# Altium schematic sheet Controller Board - Microcontroller.SchDoc
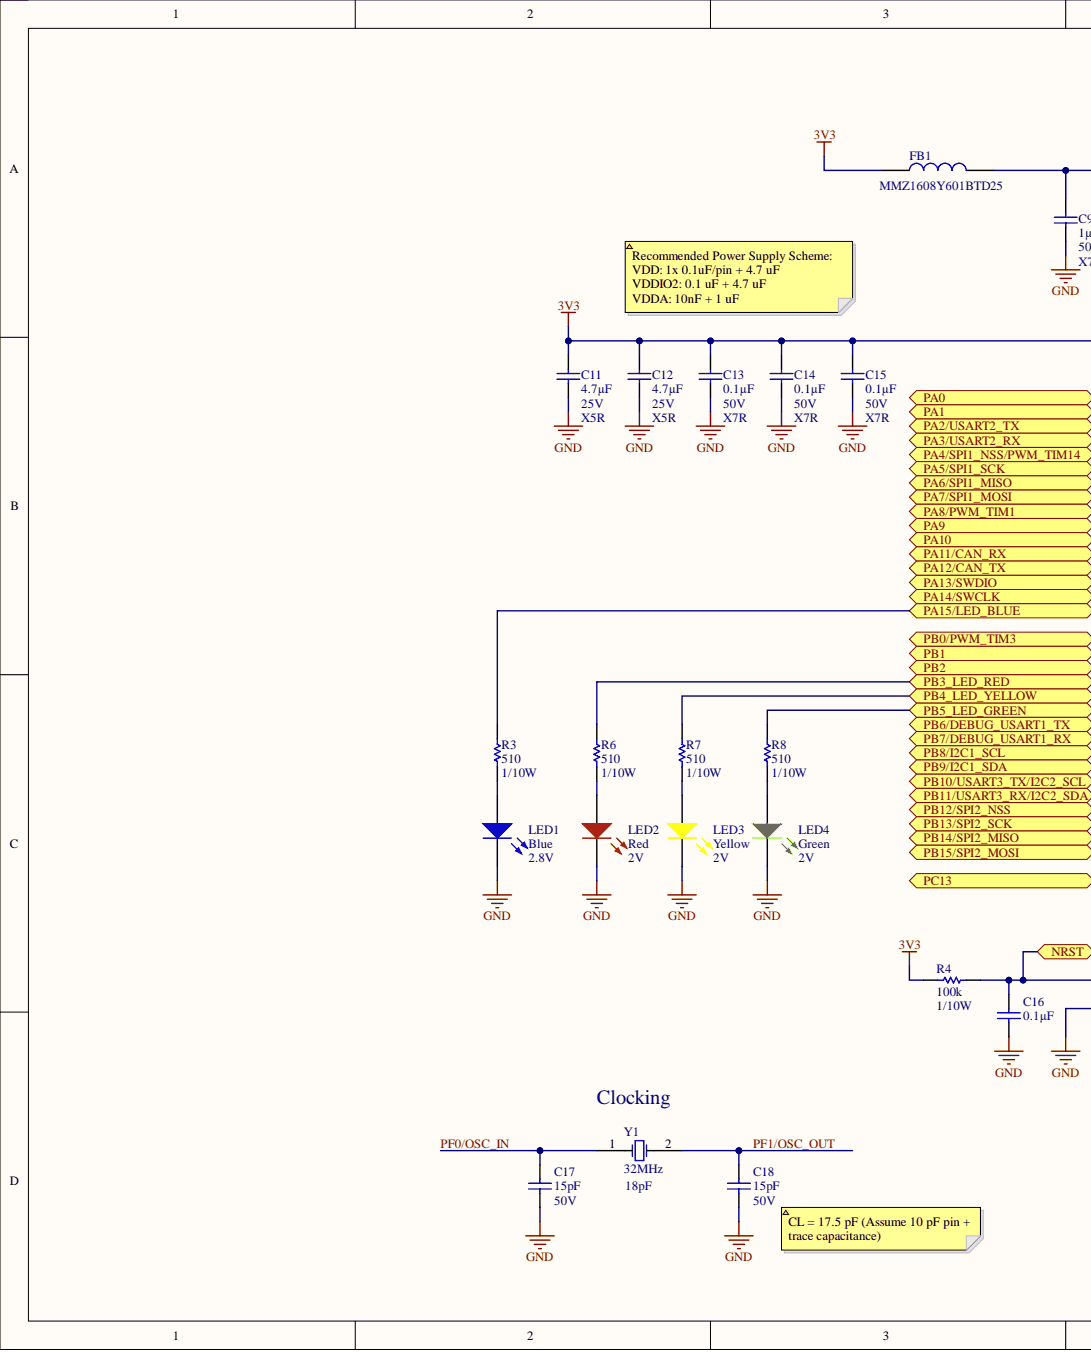
[diagram p0: Controller Board - Microcontroller.SchDoc, left]
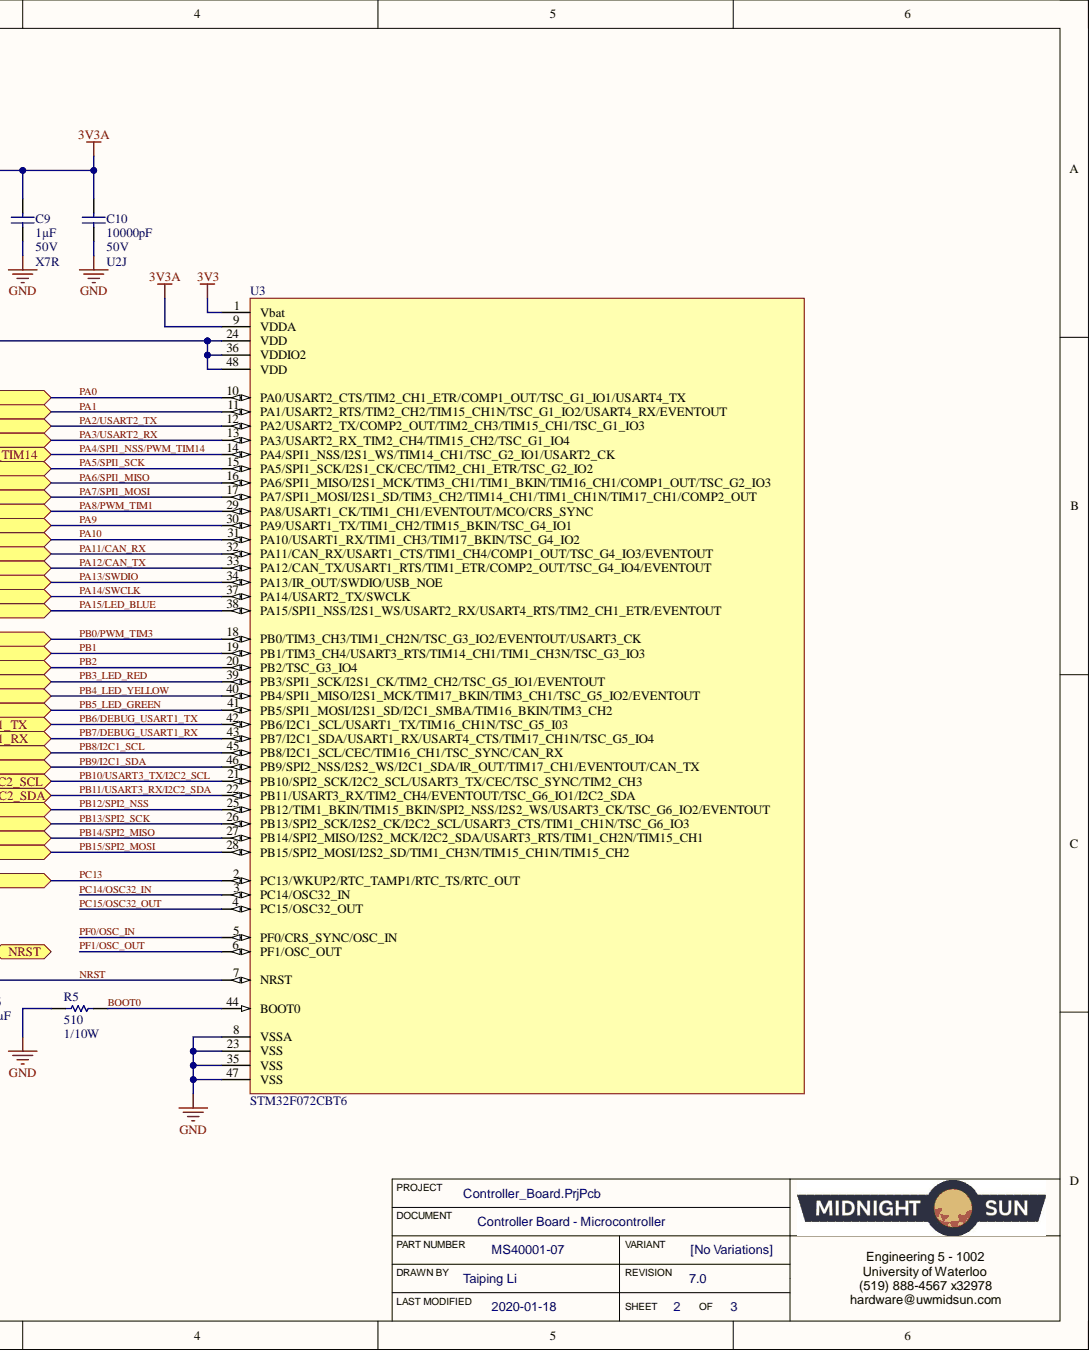
[diagram p1: Controller Board - Microcontroller.SchDoc, right]

## Parts
C9  Capacitance: 1µF  Temperature Coefficient: X7R  Voltage: 50V  [CAP, 0603]  (hidden comment: CAP CER 1UF 50V 10% X7R 0603)
C10  Capacitance: 10000pF  Temperature Coefficient: U2J  Voltage: 50V  [CAP, 0603]  (hidden comment: CAP CER 10nF 50V 5% X7R 0603)
C11  Capacitance: 4.7µF  Temperature Coefficient: X5R  Voltage: 25V  [CAP, 0603]  (hidden comment: CAP CER 4.7UF 25V 10% X5R 0603)
C12  Capacitance: 4.7µF  Temperature Coefficient: X5R  Voltage: 25V  [CAP, 0603]  (hidden comment: CAP CER 4.7UF 25V 10% X5R 0603)
C13  Capacitance: 0.1µF  Temperature Coefficient: X7R  Voltage: 50V  [CAP, 0603]  (hidden comment: CAP CER 0.1UF 50V 10% X7R 0603)
C14  Capacitance: 0.1µF  Temperature Coefficient: X7R  Voltage: 50V  [CAP, 0603]  (hidden comment: CAP CER 0.1UF 50V 10% X7R 0603)
C15  Capacitance: 0.1µF  Temperature Coefficient: X7R  Voltage: 50V  [CAP, 0603]  (hidden comment: CAP CER 0.1UF 50V 10% X7R 0603)
C16  Capacitance: 0.1µF  [CAP, 0603]  (hidden comment: CAP CER 0.1UF 50V 10% X7R 0603)
C17  Capacitance: 15pF  Voltage: 50V  [CAP, 0603]  (hidden comment: CAP CER 15PF 50V ±5% NPO 0603)
C18  Capacitance: 15pF  Voltage: 50V  [CAP, 0603]  (hidden comment: CAP CER 15PF 50V ±5% NPO 0603)
FB1  Manufacturer Part Number: MMZ1608Y601BTD25  [FB 0603]  (hidden comment: FB 600 OHM 1LN 0603)
LED1  Color: Blue  Voltage - Forward (Vf) (Typ): 2.8V  [LED_0603]  (hidden comment: LED BLUE CLEAR 2.8V 0603)
LED2  Color: Red  Voltage - Forward (Vf) (Typ): 2V  [LED_0603]  (hidden comment: LED RED CLEAR 2V 0603)
LED3  Color: Yellow  Voltage - Forward (Vf) (Typ): 2V  [LED_0603]  (hidden comment: LED YELLOW CLEAR 2.1V 0603)
LED4  Color: Green  Voltage - Forward (Vf) (Typ): 2V  [LED_0603]  (hidden comment: LED GREEN CLEAR 2V 0603)
R3  Power: 1/10W  [RES, 0603]  (hidden comment: RES 510 OHM 5% 1/10W 0603)
R4  Power: 1/10W  Resistance: 100k  [RES, 0603]  (hidden comment: RES 100K OHM 5% 1/8W 0603)
R5  Power: 1/10W  [RES, 0603]  (hidden comment: RES 510 OHM 5% 1/10W 0603)
R6  Power: 1/10W  [RES, 0603]  (hidden comment: RES 510 OHM 5% 1/10W 0603)
R7  Power: 1/10W  [RES, 0603]  (hidden comment: RES 510 OHM 5% 1/10W 0603)
R8  Power: 1/10W  [RES, 0603]  (hidden comment: RES 510 OHM 5% 1/10W 0603)
U3  Manufacturer Part Number 1: STM32F072CBT6  [TSQFP50P890X890X160-48M]  (hidden comment: IC MCU 32BIT 128KB FLASH 48LQFP)
Y1  Frequency: 32MHz  Load Capacitance: 18pF  [CRYSTAL ABM7]  (hidden comment: CRYSTAL 32 MHz 18PF 2-SMD)

## Ports
NRST, PA0, PA1, PA2/USART2_TX, PA3/USART2_RX, PA4/SPI1_NSS/PWM_TIM14, PA5/SPI1_SCK, PA6/SPI1_MISO, PA7/SPI1_MOSI, PA8/PWM_TIM1, PA9, PA10, PA11/CAN_RX, PA12/CAN_TX, PA13/SWDIO, PA14/SWCLK, PA15/LED_BLUE, PB0/PWM_TIM3, PB1, PB2, PB3_LED_RED, PB4_LED_YELLOW, PB5_LED_GREEN, PB6/DEBUG_USART1_TX, PB7/DEBUG_USART1_RX, PB8/I2C1_SCL, PB9/I2C1_SDA, PB10/USART3_TX/I2C2_SCL, PB11/USART3_RX/I2C2_SDA, PB12/SPI2_NSS, PB13/SPI2_SCK, PB14/SPI2_MISO, PB15/SPI2_MOSI, PC13

## Nets
3V3: C11.1 C12.1 C13.1 C14.1 C15.1 FB1.1 R4.2 U3.1(Vbat) U3.24(VDD) U3.36(VDDIO2) U3.48(VDD)
3V3A: C9.1 C10.1 FB1.2 U3.9(VDDA)
GND: C9.2 C10.2 C11.2 C12.2 C13.2 C14.2 C15.2 C16.1 C17.1 C18.1 LED1.2 LED2.2 LED3.2 LED4.2 R5.1 U3.8(VSSA) U3.23(VSS) U3.35(VSS) U3.47(VSS)
BOOT0: R5.2 U3.44(BOOT0)
NRST: C16.2 R4.1 U3.7(NRST) ; port NRST
PA0: U3.10(PA0/USART2_CTS/TIM2_CH1_ETR/COMP1_OUT/TSC_G1_IO1/USART4_TX) ; port PA0
PA1: U3.11(PA1/USART2_RTS/TIM2_CH2/TIM15_CH1N/TSC_G1_IO2/USART4_RX/EVENTOUT) ; port PA1
PA2/USART2_TX: U3.12(PA2/USART2_TX/COMP2_OUT/TIM2_CH3/TIM15_CH1/TSC_G1_IO3) ; port PA2/USART2_TX
PA3/USART2_RX: U3.13(PA3/USART2_RX_TIM2_CH4/TIM15_CH2/TSC_G1_IO4) ; port PA3/USART2_RX
PA4/SPI1_NSS/PWM_TIM14: U3.14(PA4/SPI1_NSS/I2S1_WS/TIM14_CH1/TSC_G2_IO1/USART2_CK) ; port PA4/SPI1_NSS/PWM_TIM14
PA5/SPI1_SCK: U3.15(PA5/SPI1_SCK/I2S1_CK/CEC/TIM2_CH1_ETR/TSC_G2_IO2) ; port PA5/SPI1_SCK
PA6/SPI1_MISO: U3.16(PA6/SPI1_MISO/I2S1_MCK/TIM3_CH1/TIM1_BKIN/TIM16_CH1/COMP1_OUT/TSC_G2_IO3) ; port PA6/SPI1_MISO
PA7/SPI1_MOSI: U3.17(PA7/SPI1_MOSI/I2S1_SD/TIM3_CH2/TIM14_CH1/TIM1_CH1N/TIM17_CH1/COMP2_OUT) ; port PA7/SPI1_MOSI
PA8/PWM_TIM1: U3.29(PA8/USART1_CK/TIM1_CH1/EVENTOUT/MCO/CRS_SYNC) ; port PA8/PWM_TIM1
PA9: U3.30(PA9/USART1_TX/TIM1_CH2/TIM15_BKIN/TSC_G4_IO1) ; port PA9
PA10: U3.31(PA10/USART1_RX/TIM1_CH3/TIM17_BKIN/TSC_G4_IO2) ; port PA10
PA11/CAN_RX: U3.32(PA11/CAN_RX/USART1_CTS/TIM1_CH4/COMP1_OUT/TSC_G4_IO3/EVENTOUT) ; port PA11/CAN_RX
PA12/CAN_TX: U3.33(PA12/CAN_TX/USART1_RTS/TIM1_ETR/COMP2_OUT/TSC_G4_IO4/EVENTOUT) ; port PA12/CAN_TX
PA13/SWDIO: U3.34(PA13/IR_OUT/SWDIO/USB_NOE) ; port PA13/SWDIO
PA14/SWCLK: U3.37(PA14/USART2_TX/SWCLK) ; port PA14/SWCLK
PA15/LED_BLUE: R3.1 U3.38(PA15/SPI1_NSS/I2S1_WS/USART2_RX/USART4_RTS/TIM2_CH1_ETR/EVENTOUT) ; port PA15/LED_BLUE
PB0/PWM_TIM3: U3.18(PB0/TIM3_CH3/TIM1_CH2N/TSC_G3_IO2/EVENTOUT/USART3_CK) ; port PB0/PWM_TIM3
PB1: U3.19(PB1/TIM3_CH4/USART3_RTS/TIM14_CH1/TIM1_CH3N/TSC_G3_IO3) ; port PB1
PB2: U3.20(PB2/TSC_G3_IO4) ; port PB2
PB3_LED_RED: R6.1 U3.39(PB3/SPI1_SCK/I2S1_CK/TIM2_CH2/TSC_G5_IO1/EVENTOUT) ; port PB3_LED_RED
PB4_LED_YELLOW: R7.1 U3.40(PB4/SPI1_MISO/I2S1_MCK/TIM17_BKIN/TIM3_CH1/TSC_G5_IO2/EVENTOUT) ; port PB4_LED_YELLOW
PB5_LED_GREEN: R8.1 U3.41(PB5/SPI1_MOSI/I2S1_SD/I2C1_SMBA/TIM16_BKIN/TIM3_CH2) ; port PB5_LED_GREEN
PB6/DEBUG_USART1_TX: U3.42(PB6/I2C1_SCL/USART1_TX/TIM16_CH1N/TSC_G5_I03) ; port PB6/DEBUG_USART1_TX
PB7/DEBUG_USART1_RX: U3.43(PB7/I2C1_SDA/USART1_RX/USART4_CTS/TIM17_CH1N/TSC_G5_IO4) ; port PB7/DEBUG_USART1_RX
PB8/I2C1_SCL: U3.45(PB8/I2C1_SCL/CEC/TIM16_CH1/TSC_SYNC/CAN_RX) ; port PB8/I2C1_SCL
PB9/I2C1_SDA: U3.46(PB9/SPI2_NSS/I2S2_WS/I2C1_SDA/IR_OUT/TIM17_CH1/EVENTOUT/CAN_TX) ; port PB9/I2C1_SDA
PB10/USART3_TX/I2C2_SCL: U3.21(PB10/SPI2_SCK/I2C2_SCL/USART3_TX/CEC/TSC_SYNC/TIM2_CH3) ; port PB10/USART3_TX/I2C2_SCL
PB11/USART3_RX/I2C2_SDA: U3.22(PB11/USART3_RX/TIM2_CH4/EVENTOUT/TSC_G6_IO1/I2C2_SDA) ; port PB11/USART3_RX/I2C2_SDA
PB12/SPI2_NSS: U3.25(PB12/TIM1_BKIN/TIM15_BKIN/SPI2_NSS/I2S2_WS/USART3_CK/TSC_G6_IO2/EVENTOUT) ; port PB12/SPI2_NSS
PB13/SPI2_SCK: U3.26(PB13/SPI2_SCK/I2S2_CK/I2C2_SCL/USART3_CTS/TIM1_CH1N/TSC_G6_IO3) ; port PB13/SPI2_SCK
PB14/SPI2_MISO: U3.27(PB14/SPI2_MISO/I2S2_MCK/I2C2_SDA/USART3_RTS/TIM1_CH2N/TIM15_CH1) ; port PB14/SPI2_MISO
PB15/SPI2_MOSI: U3.28(PB15/SPI2_MOSI/I2S2_SD/TIM1_CH3N/TIM15_CH1N/TIM15_CH2) ; port PB15/SPI2_MOSI
PC13: U3.2(PC13/WKUP2/RTC_TAMP1/RTC_TS/RTC_OUT) ; port PC13
PC14/OSC32_IN: U3.3(PC14/OSC32_IN)
PC15/OSC32_OUT: U3.4(PC15/OSC32_OUT)
PF0/OSC_IN: C17.2 U3.5(PF0/CRS_SYNC/OSC_IN) Y1.1
PF1/OSC_OUT: C18.2 U3.6(PF1/OSC_OUT) Y1.2
NetLED1_1: LED1.1 R3.2
NetLED2_1: LED2.1 R6.2
NetLED3_1: LED3.1 R7.2
NetLED4_1: LED4.1 R8.2

## Library data of the parts
C9 = CAP CER 1UF 50V 10% X7R 0603: 1µF ±10% 50V Ceramic Capacitor X7R 0603 (1608 Metric); Manufacturer 1: Taiyo Yuden; Manufacturer Part Number 1: UMK107AB7105KA-T; Component Kind: Standard; ComponentLink1Description: http://www.yuden.co.jp/productdata/catalog/en/mlcc_all_e.pdf; ComponentLink1URL: http://www.yuden.co.jp/productdata/catalog/en/mlcc_all_e.pdf; Height - Seated (Max): -; Lead Spacing: -; Lead Style: -; Mounting Type: Surface Mount, MLCC; Operating Temperature: -55°C ~ 125°C; Package / Case: 0603 (1608 Metric); Packaging: Cut Tape (CT); Part Status: Active; Ratings: -; Size / Dimension: 0.063" L x 0.031" W (1.60mm x 0.80mm); Supplier 1: Digi-Key; Supplier Part Number 1: 587-3247-1-ND; Thickness (Max): 0.037" (0.95mm); Tolerance: ±10%; Library Name: Schematic Diagrams.SchLib
C10 = CAP CER 10nF 50V 5% X7R 0603: 10000pF ±5% 50V Ceramic Capacitor U2J 0603 (1608 Metric); Manufacturer 1: KEMET; Manufacturer Part Number 1: C0603C103J5JAC7867; Component Kind: Standard; ComponentLink1Description: http://www.kemet.com/docfinder?Partnumber=C0603C103J5JAC7867; ComponentLink1URL: http://www.kemet.com/docfinder?Partnumber=C0603C103J5JAC7867; Failure Rate: -; Height - Seated (Max): -; Lead Spacing: -; Lead Style: -; Mounting Type: Surface Mount, MLCC; Operating Temperature: -55°C ~ 125°C; Package / Case: 0603 (1608 Metric); Packaging: Cut Tape (CT); Part Status: Active; Ratings: -; Size / Dimension: 0.063" L x 0.032" W (1.60mm x 0.80mm); Supplier 1: Digi-Key; Supplier Part Number 1: 399-13384-1-ND; Thickness (Max): 0.034" (0.87mm); Tolerance: ±5%; Library Name: Schematic Diagrams.SchLib
C11 C12 = CAP CER 4.7UF 25V 10% X5R 0603: 4.7µF ±10% 25V Ceramic Capacitor X5R 0603 (1608 Metric); Manufacturer 1: Murata Electronics North America; Manufacturer Part Number 1: GRM188R61E475KE11D; Component Kind: Standard; ComponentLink1Description: http://www.murata.com/~/media/webrenewal/support/library/catalog/products/capacitor/mlcc/c02e.ashx; ComponentLink1URL: http://www.murata.com/~/media/webrenewal/support/library/catalog/products/capacitor/mlcc/c02e.ashx; Height - Seated (Max): -; Lead Spacing: -; Lead Style: -; Mounting Type: Surface Mount, MLCC; Operating Temperature: -55°C ~ 85°C; Package / Case: 0603 (1608 Metric); Packaging: Cut Tape (CT); Part Status: Active; Ratings: -; Size / Dimension: 0.063" L x 0.031" W (1.60mm x 0.80mm); Supplier 1: Digi-Key; Supplier Part Number 1: 490-7203-1-ND; Thickness (Max): 0.031" (0.80mm); Tolerance: ±10%; Library Name: Schematic Diagrams.SchLib
C13-C16 = CAP CER 0.1UF 50V 10% X7R 0603: 0.10µF ±10% 50V Ceramic Capacitor X7R 0603 (1608 Metric); Manufacturer 1: AVX Corporation; Manufacturer Part Number 1: 06035C104KAT2A; Component Kind: Standard; ComponentLink1Description: http://datasheets.avx.com/X7RDielectric.pdf; ComponentLink1URL: http://datasheets.avx.com/X7RDielectric.pdf; Height - Seated (Max): -; Lead Spacing: -; Lead Style: -; Mounting Type: Surface Mount, MLCC; Operating Temperature: -55°C ~ 125°C; Package / Case: 0603 (1608 Metric); Packaging: Cut Tape (CT); Part Status: Active; Ratings: -; Size / Dimension: 0.063" L x 0.032" W (1.60mm x 0.81mm); Supplier 1: Digi-Key; Supplier Part Number 1: 478-5052-1-ND; Thickness (Max): 0.035" (0.90mm); Tolerance: ±10%; Library Name: Schematic Diagrams.SchLib
  C16: Temperature Coefficient: X7R; Voltage: 50V
C17 C18 = CAP CER 15PF 50V ±5% NPO 0603: 15pF ±5% 50V Ceramic Capacitor C0G, NP0 0603 (1608 Metric); Manufacturer 1: Yageo; Manufacturer Part Number 1: CC0603JRNPO9BN150; Component Kind: Standard; ComponentLink1Description: http://www.yageo.com/documents/recent/UPY-GP_NP0_16V-to-50V_16.pdf; ComponentLink1URL: http://www.yageo.com/documents/recent/UPY-GP_NP0_16V-to-50V_16.pdf; Failure Rate: -; Height - Seated (Max): -; Lead Spacing: -; Lead Style: -; Mounting Type: Surface Mount, MLCC; Operating Temperature: -55°C ~ 125°C; Package / Case: 0603 (1608 Metric); Packaging: Cut Tape (CT); Part Status: Active; Ratings: -; Size / Dimension: 0.063" L x 0.031" W (1.60mm x 0.80mm); Supplier 1: Digi-Key; Supplier Part Number 1: 311-1060-1-ND; Temperature Coefficient: C0G, NP0; Thickness (Max): 0.035" (0.90mm); Tolerance: ±5%; Library Name: Schematic Diagrams.SchLib
FB1 = FB 600 OHM 1LN 0603: FERRITE BEAD 600 OHM 0603 1LN; Manufacturer: TDK; DC Resistance (DCR): 400mR; Impedance: 600R; Max Current Rating: 500mA; Number of Lines: 1; Test Frequency: 100MHz; Tolerance: 25%; Case Code (Imperial): 0603; Case Code (Metric): 1608; Case/Package: 0603; Component Kind: Standard; Height: 0.037inch; Length: 0.063inch; Max DC Current: 500mA; Max Operating Temperature: 125°C; Min Operating Temperature: -55°C; Mount: Surface Mount; Package Quantity: 4000; Packaging: Tape and Reel; Pricing 1: 1 = 0.10000, 10 = 0.07600, 25 = 0.06840, 50 = 0.05000, 100 = 0.04330, 250 = 0.03416, 500 = 0.02884, 1000 = 0.02429 (USD); Ratings: AEC-Q200; RoHS: Yes; Stock 1: 19540; Supplier 1: Digi-Key; Supplier Part Number 1: 445-172850-1-ND; Termination: SMD/SMT; Weight: 7.1E-05oz; Width: 0.031inch; Library Name: Schematic Diagrams.SchLib
LED1 = LED BLUE CLEAR 2.8V 0603: Blue 470nm LED Indication - Discrete 2.8V 0603 (1608 Metric); Manufacturer 1: Lite-On Inc.; Manufacturer Part Number 1: LTST-C193TBKT-5A; Current - Test: 5mA; Lens Color: Colorless; Lens Style/Size: Rectangle with Flat Top, 1.15mm x 0.80mm; Millicandela Rating: 15mcd; Viewing Angle: 130°; Wavelength - Peak: 468nm; Component Kind: Standard; ComponentLink1Description: http://optoelectronics.liteon.com/upload/download/DS22-2004-060/P_100_LTST-C193TBKT-5A.pdf; ComponentLink1URL: http://optoelectronics.liteon.com/upload/download/DS22-2004-060/P_100_LTST-C193TBKT-5A.pdf; Height (Max): 0.45mm; Lens Transparency: Clear; Mounting Type: Surface Mount; Package / Case: 0603 (1608 Metric); Packaging: Cut Tape (CT); Part Status: Active; Size / Dimension: 1.60mm L x 0.80mm W; Supplier 1: Digi-Key; Supplier Device Package: Chip LED; Supplier Part Number 1: 160-1827-1-ND; Wavelength - Dominant: 470nm; Library Name: Schematic Diagrams.SchLib
LED2 = LED RED CLEAR 2V 0603: Red 631nm LED Indication - Discrete 2V 0603 (1608 Metric); Manufacturer: Wurth Electronics Inc.; Manufacturer Part Number: 150060RS75000; Current - Test: 20mA; Lens Color: Colorless; Lens Style/Size: Rectangle with Flat Top, 1.10mm x 0.70mm; Millicandela Rating: 250mcd; Viewing Angle: 140°; Wavelength - Dominant: 625nm; Wavelength - Peak: 630nm; Category: Optoelectronics; Component Kind: Standard; ComponentLink1Description: http://katalog.we-online.de/led/datasheet/150060RS75000.pdf; ComponentLink1URL: http://katalog.we-online.de/led/datasheet/150060RS75000.pdf; Features: -; Height (Max): 0.80mm; Lens Transparency: Clear; Mounting Type: Surface Mount; Package / Case: 0603 (1608 Metric); Packaging: Cut Tape (CT); Part Status: Active; Pricing 1: 1=0.21, 100=0.1617, 500=0.13964 (CAD); RoHS: RoHS Compliant; Size / Dimension: 1.60mm L x 0.80mm W; Stock 1: 35521; Supplier 1: Digi-Key; Supplier Device Package: 0603; Supplier Part Number 1: 732-4978-1-ND; Library Name: Schematic Diagrams.SchLib
LED3 = LED YELLOW CLEAR 2.1V 0603: Yellow 590nm LED Indication - Discrete 2V 0603 (1608 Metric); Manufacturer: Wurth Electronics Inc.; Manufacturer Part Number: 150060YS75000; Current - Test: 20mA; Lens Color: Colorless; Lens Style/Size: Rectangle with Flat Top, 1.10mm x 0.70mm; Millicandela Rating: 120mcd; Viewing Angle: 140°; Wavelength - Peak: 595nm; Category: Optoelectronics; Component Kind: Standard; ComponentLink1Description: http://katalog.we-online.de/led/datasheet/150060YS75000.pdf; ComponentLink1URL: http://katalog.we-online.de/led/datasheet/150060YS75000.pdf; Features: -; Height (Max): 0.80mm; Lens Transparency: Clear; Mounting Type: Surface Mount; Package / Case: 0603 (1608 Metric); Packaging: Cut Tape (CT); Part Status: Active; Pricing 1: 1=0.21, 100=0.1617, 500=0.13964 (CAD); RoHS: RoHS Compliant; Size / Dimension: 1.60mm L x 0.80mm W; Stock 1: 10523; Supplier 1: Digi-Key; Supplier Device Package: 0603; Supplier Part Number 1: 732-4981-1-ND; Wavelength - Dominant: 590nm; Library Name: Schematic Diagrams.SchLib
LED4 = LED GREEN CLEAR 2V 0603: Green 572nm LED Indication - Discrete 2V 0603 (1608 Metric); Manufacturer: Wurth Electronics Inc.; Manufacturer Part Number: 150060VS75000; Current - Test: 20mA; Lens Color: Colorless; Lens Style/Size: Rectangle with Flat Top, 1.10mm x 0.70mm; Millicandela Rating: 40mcd; Viewing Angle: 140°; Wavelength - Dominant: 570nm; Category: Optoelectronics; Component Kind: Standard; ComponentLink1Description: http://katalog.we-online.de/led/datasheet/150060VS75000.pdf; ComponentLink1URL: http://katalog.we-online.de/led/datasheet/150060VS75000.pdf; Features: -; Height (Max): 0.80mm; Lens Transparency: Clear; Mounting Type: Surface Mount; Package / Case: 0603 (1608 Metric); Packaging: Cut Tape (CT); Part Status: Active; Pricing 1: 1=0.21, 100=0.1617, 500=0.13964 (CAD); RoHS: RoHS Compliant; Size / Dimension: 1.60mm L x 0.80mm W; Stock 1: 9408; Supplier 1: Digi-Key; Supplier Device Package: 0603; Supplier Part Number 1: 732-4980-1-ND; Wavelength - Peak: 572nm; Library Name: Schematic Diagrams.SchLib
R3 R5-R8 = RES 510 OHM 5% 1/10W 0603: RES SMD 510 OHM 5% 1/10W 0603; Manufacturer 1: Yageo; Manufacturer Part Number 1: RC0603JR-07510RL; Resistance: 510; Temperature Coefficient: ±100ppm/°C; Component Kind: Standard; ComponentLink1Description: http://www.yageo.com/documents/recent/PYu-RC_Group_51_RoHS_L_7.pdf; ComponentLink1URL: http://www.yageo.com/documents/recent/PYu-RC_Group_51_RoHS_L_7.pdf; Composition: Thick Film; Failure Rate: -; Height - Seated (Max): 0.022" (0.55mm); Operating Temperature: -55°C ~ 155°C; Package / Case: 0603 (1608 Metric); Packaging: Cut Tape (CT); Part Status: Active; Size / Dimension: 0.063" L x 0.031" W (1.60mm x 0.80mm); Supplier 1: Digi-Key; Supplier Device Package: 0603; Supplier Part Number 1: 311-510GRCT-ND; Tolerance: ±5%
  R5: Library Name: Schematic Diagrams.SchLib
R4 = RES 100K OHM 5% 1/8W 0603: RES SMD 100K OHM 5% 1/10W 0603; Manufacturer 1: Yageo; Manufacturer Part Number 1: RC0603JR-07100KL; Temperature Coefficient: ±100ppm/°C; Component Kind: Standard; ComponentLink1Description: http://www.yageo.com/documents/recent/PYu-RC_Group_51_RoHS_L_7.pdf; ComponentLink1URL: http://www.yageo.com/documents/recent/PYu-RC_Group_51_RoHS_L_7.pdf; Composition: Thick Film; Failure Rate: -; Height - Seated (Max): 0.022" (0.55mm); Operating Temperature: -55°C ~ 155°C; Package / Case: 0603 (1608 Metric); Packaging: Cut Tape (CT); Part Status: Active; Size / Dimension: 0.063" L x 0.031" W (1.60mm x 0.80mm); Supplier 1: Digi-Key; Supplier Device Package: 0603; Supplier Part Number 1: 311-100KGRCT-ND; Tolerance: ±5%; Library Name: Schematic Diagrams.SchLib
U3 = IC MCU 32BIT 128KB FLASH 48LQFP: ARM® Cortex®-M0 STM32 F0 Microcontroller IC 32-Bit 48MHz 128KB (128K x 8) FLASH 48-LQFP (7x7); Manufacturer 1: STMicroelectronics; Connectivity: CAN, HDMI-CEC, I²C, IrDA, LIN, SPI, UART/USART, USB; Data Converters: A/D 10x12b, D/A 2x12b; Number of I/O: 37; Oscillator Type: Internal; Peripherals: DMA, I²S, POR, PWM, WDT; RAM Size: 16K x 8; Voltage - Supply (Vcc/Vdd): 1.65 V ~ 3.6 V; Component Kind: Standard; ComponentLink1Description: http://www.st.com/content/ccc/resource/technical/document/datasheet/cd/46/43/83/22/d3/40/c8/DM00090510.pdf/files/DM00090510.pdf/jcr:content/translations/en.DM00090510.pdf; ComponentLink1URL: http://www.st.com/content/ccc/resource/technical/document/datasheet/cd/46/43/83/22/d3/40/c8/DM00090510.pdf/files/DM00090510.pdf/jcr:content/translations/en.DM00090510.pdf; Core Processor: ARM® Cortex®-M0; Core Size: 32-Bit; EEPROM Size: -; Operating Temperature: -40°C ~ 85°C (TA); Package / Case: 48-LQFP; Packaging: Tray; Part Status: Active; Program Memory Size: 128KB (128K x 8); Program Memory Type: FLASH; Speed: 48MHz; Supplier 1: Digi-Key; Supplier Device Package: 48-LQFP (7x7); Supplier Part Number 1: 497-14645-ND; Library Name: Schematic Diagrams.SchLib
Y1 = CRYSTAL 32 MHz 18PF 2-SMD: 32MHz ±20ppm Crystal 18pF 50 Ohms 2-SMD, No Lead; Manufacturer: Abracon; Manufacturer Part Number: ABM7-32.000MHZ-D2Y-T; ESR (Equivalent Series Resistance): 50R; Frequency Stability: 30ppm; Case/Package: CSMD; Component Kind: Standard; ComponentLink1Description: https://abracon.com/Resonators/abm3.pdf; ComponentLink1URL: https://abracon.com/Resonators/abm3.pdf; ComponentLink2Description: http://www.farnell.com/datasheets/947971.pdf?src-supplier=Element14; ComponentLink2URL: http://www.farnell.com/datasheets/947971.pdf?src-supplier=Element14; ComponentLink3Description: http://www.abracon.com/Resonators/abm7.pdf?src-supplier=Future+Electronics; ComponentLink3URL: http://www.abracon.com/Resonators/abm7.pdf?src-supplier=Future+Electronics; ComponentLink4Description: http://www.abracon.com/Resonators/abm7.pdf?src-supplier=Digi-Key; ComponentLink4URL: http://www.abracon.com/Resonators/abm7.pdf?src-supplier=Digi-Key; ComponentLink5Description: https://abracon.com/Resonators/abm7.pdf?src-supplier=Digi-Key; ComponentLink5URL: https://abracon.com/Resonators/abm7.pdf?src-supplier=Digi-Key; ComponentLink6Description: http://www.abracon.com/Resonators/abm7.pdf?src-supplier=Avnet; ComponentLink6URL: http://www.abracon.com/Resonators/abm7.pdf?src-supplier=Avnet; Composition: Ceramic; Depth: 3.5mm; Frequency Tolerance: 20ppm; Height: 0.055inch; Lead Free: Lead Free; Length: 6mm; Max Operating Temperature: 85°C; Min Operating Temperature: -40°C; Mount: Surface Mount; Number of Pins: 2; Package Quantity: 1000; Packaging: Tape and Reel; Pricing 1: 1 = 1.00000, 10 = 0.88600, 50 = 0.82780, 100 = 0.73150, 500 = 0.69300 (USD); Radiation Hardening: No; REACH SVHC: Unknown; RoHS: Yes; Series Resistance: 50R; Stock 1: 3351; Supplier 1: Digi-Key; Supplier Part Number 1: 535-9850-1-ND; Termination: SMD/SMT; Tolerance: 20ppm; Width: 3.3mm; Library Name: Schematic Diagrams.SchLib
